# Altium schematic sheet 0_Overview.SchDoc
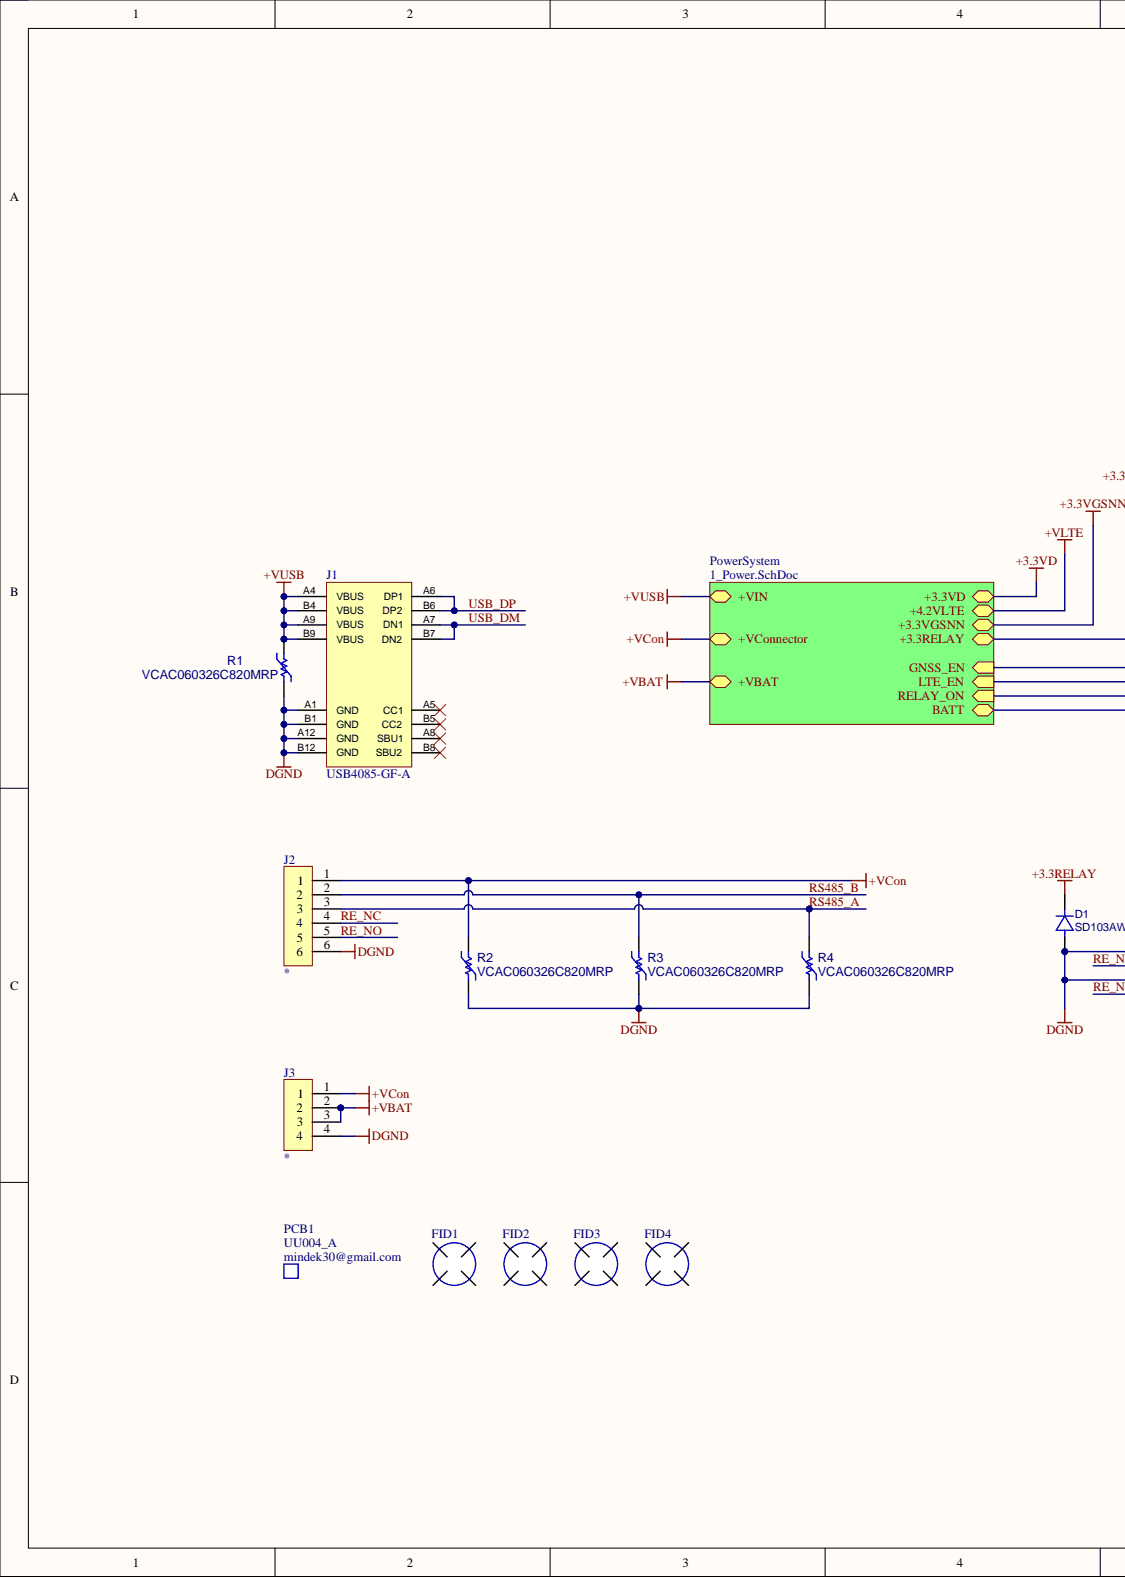
[diagram p0: 0_Overview.SchDoc, left]
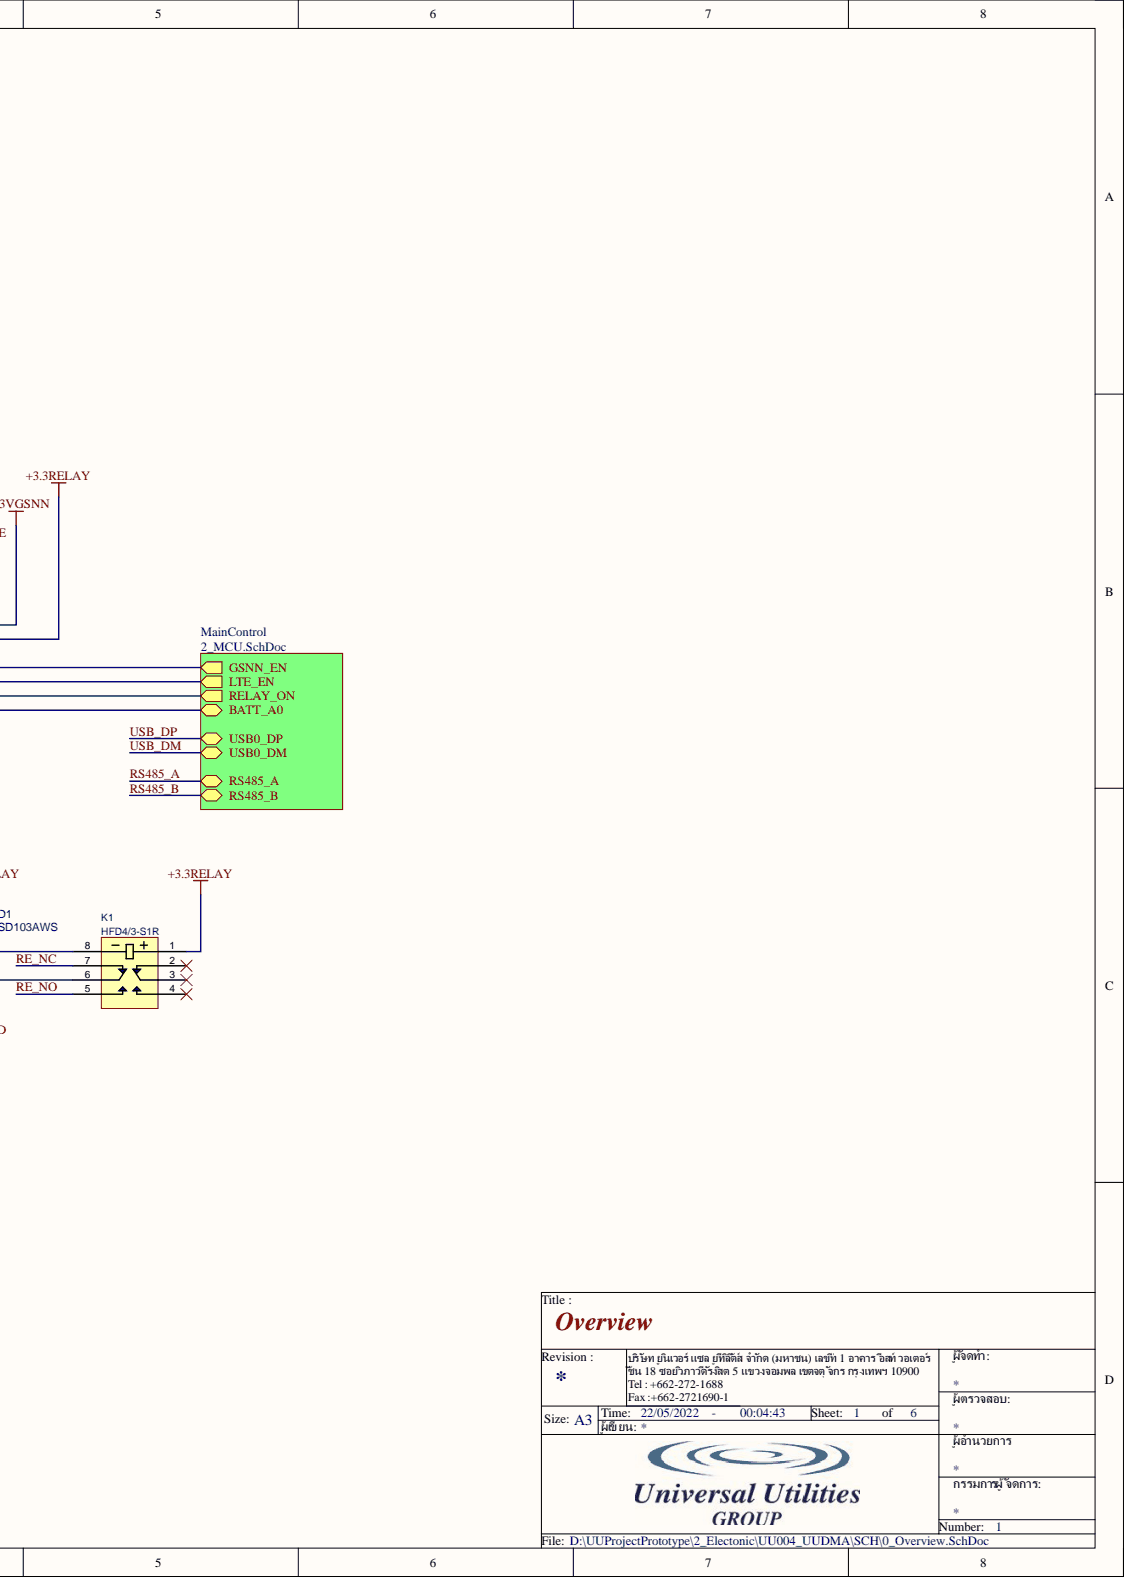
[diagram p1: 0_Overview.SchDoc, right]

## Parts
D1  SD103AWS  [SOD323]
FID1  FIDUCIAL  [FID]  (value not drawn)  (no BOM)
FID2  FIDUCIAL  [FID]  (value not drawn)  (no BOM)
FID3  FIDUCIAL  [FID]  (value not drawn)  (no BOM)
FID4  FIDUCIAL  [FID]  (value not drawn)  (no BOM)
J1  USB4085-GF-A  [GCT_USB4085-GF-A_REVA4]
J2  (no value)  [CON_6P_Header]  (no BOM)
J3  (no value)  [CON_4P_Header]  (no BOM)
K1  HFD4/3-S1R  [RELAY_HFD4/12-SR]
PCB1  UU004_A  Manufacturer: mindek30@gmail.com  (mechanical)
R1  VCAC060326C820MRP  [R_0603]
R2  VCAC060326C820MRP  [R_0603]
R3  VCAC060326C820MRP  [R_0603]
R4  VCAC060326C820MRP  [R_0603]

## Nets
+3.3RELAY: D1.1 K1.1
+VBAT: J3.2 J3.3
+VCon: J2.1 J3.1 R2.1
+VUSB: J1.A4(VBUS) J1.A9(VBUS) J1.B4(VBUS) J1.B9(VBUS) R1.2
DGND: D1.2 J1.A1(GND) J1.A12(GND) J1.B1(GND) J1.B12(GND) J2.6 J3.4 K1.6 K1.8 R1.1 R2.2 R3.1 R4.2
RE_NC: J2.4 K1.7
RE_NO: J2.5 K1.5
RS485_A: J2.3 R4.1
RS485_B: J2.2 R3.2
USB_DM: J1.A7(DN1) J1.B7(DN2)
USB_DP: J1.A6(DP1) J1.B6(DP2)
NetJ1_A5: J1.A5(CC1)
NetJ1_A8: J1.A8(SBU1)
NetJ1_B5: J1.B5(CC2)
NetJ1_B8: J1.B8(SBU2)
NetK1_2: K1.2
NetK1_3: K1.3
NetK1_4: K1.4
+3.3VD:
+3.3VGSNN:
+VLTE:
BATT:
GNSS_EN:
LTE_EN:
RELAY_ON:

## Library data of the parts
D1 = D_PW_SD103AWS: DIODE SCHOTTKY 40V 2A 0603; Manufacturer: Slkor(SLKORMICRO Elec.); Component Kind: Standard; PackageReference: SOD-323; PartID: C513472; PartNumber: SD103AWS; Value: SD103AWS; Library Name: UUSymbol.SchLib
FID1-FID4 = FID_FIDUCIAL: Manufacturer: mindek30@gmail.com; PartNumber: UU004_A; Value: Non SE; Description: Fiducial; Library Name: UUSymbol.SchLib; PackageReference: Non SE; PartID: A; Value2: Non SE; Value3: Non SE
J1 = CON_USBC_USB4085: CONN RCPT USB2.0 TYPE C 16+8POS; Manufacturer: GCT; Component Kind: Standard; PartID: 2073-USB4085-GF-ACT-ND; PartNumber: USB4085-GF-A; Value: USB4085-GF-A; Library Name: UUSymbol.SchLib
J2 = CON_6P_Heder: Connector 6 position; Library Name: UUSymbol.SchLib
J3 = CON_4P_Heder: Connector 4 position; Library Name: UUSymbol.SchLib
K1 = SW_RELAY_HFD4/12-SR: Telecom Non Latching 3VDC DPDT SMD Relays RoHS; Manufacturer: Xiamen Hongfa Electroacoustic; Component Kind: Standard; PartID: C143232; PartNumber: HFD4/3-S1R; Value: HFD4/3-S1R; Library Name: UUSymbol.SchLib
PCB1 = PCB_Bare: BARE PCB; Library Name: UUSymbol.SchLib; PackageReference: Non SE; PartID: A; PartNumber: UU004_A; Value: UU004_A; Value3: UU004_A
R1-R4 = R_VAR_VCAC060326C820MRP: VARISTOR 36V 30A 0603; Manufacturer: KYOCERA AVX; Component Kind: Standard; PackageReference: 0603; PartID: 478-6255-1-ND; PartNumber: VCAC060326C820MRP; Value: VCAC060326C820MRP; Library Name: UUSymbol.SchLib
